# Altium schematic sheet Surface.SchDoc(Surface)
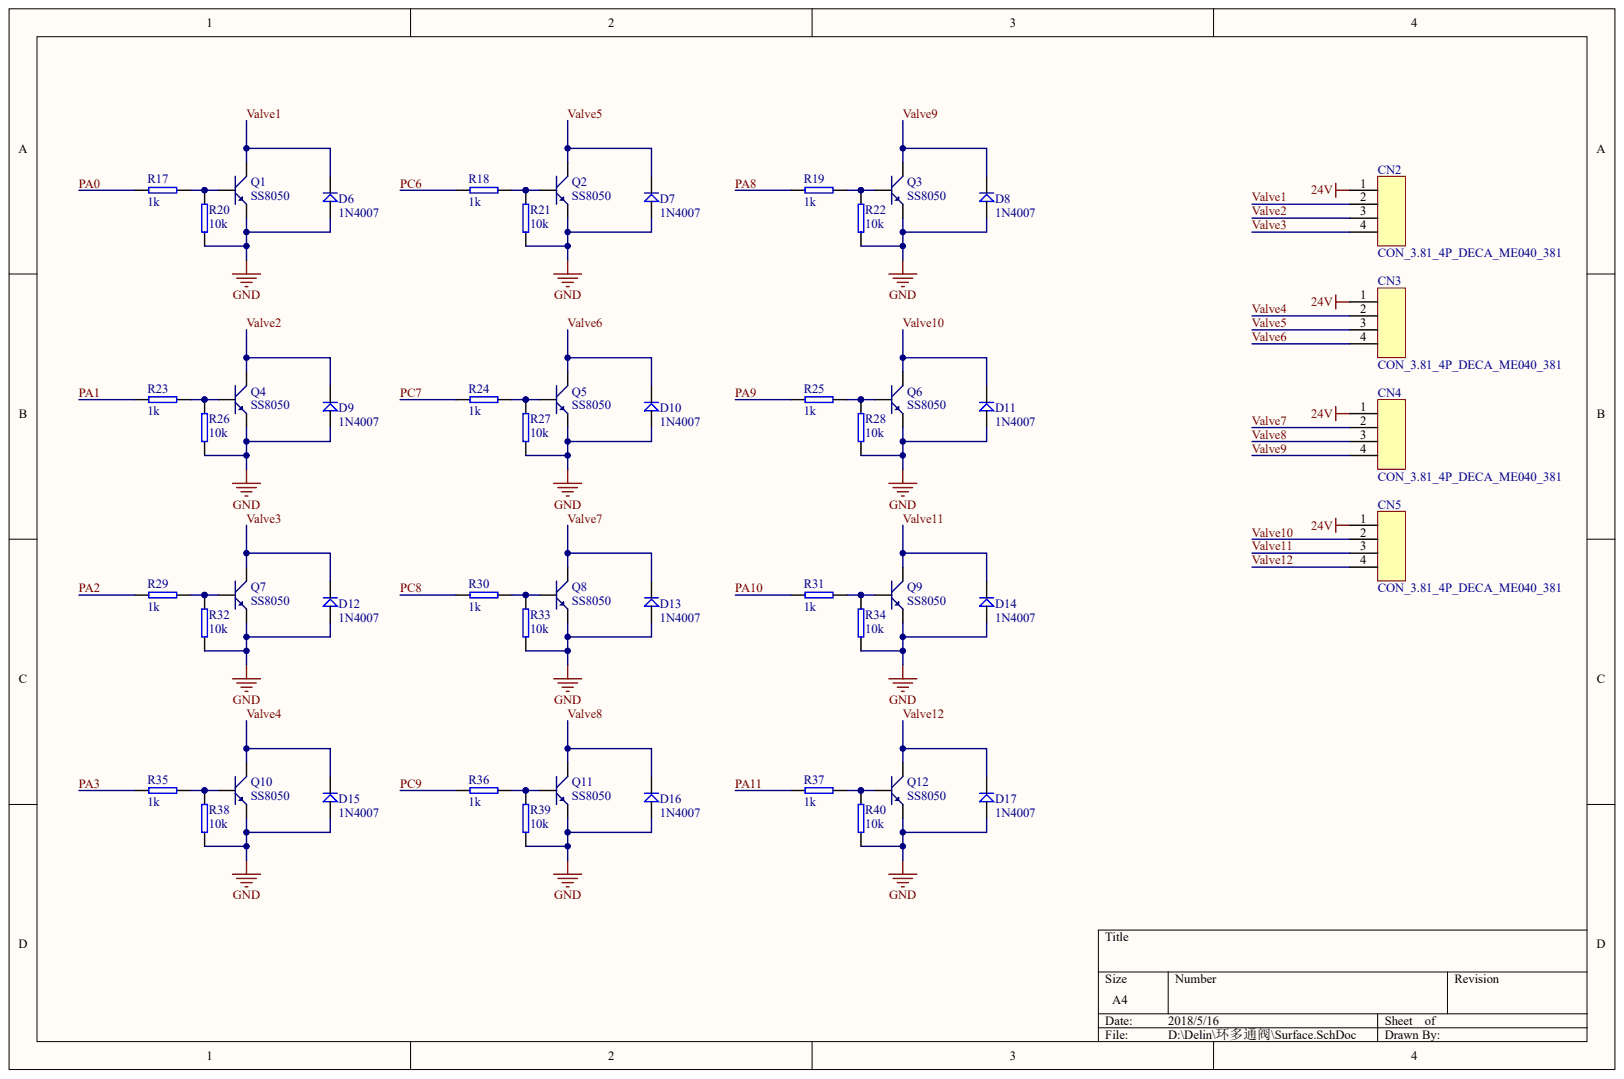
[diagram p0: Surface.SchDoc]

## Parts
CN2  CON_3.81_4P_DECA_ME040_381
CN3  CON_3.81_4P_DECA_ME040_381
CN4  CON_3.81_4P_DECA_ME040_381
CN5  CON_3.81_4P_DECA_ME040_381
D6  1N4007
D7  1N4007
D8  1N4007
D9  1N4007
D10  1N4007
D11  1N4007
D12  1N4007
D13  1N4007
D14  1N4007
D15  1N4007
D16  1N4007
D17  1N4007
Q1  SS8050
Q2  SS8050
Q3  SS8050
Q4  SS8050
Q5  SS8050
Q6  SS8050
Q7  SS8050
Q8  SS8050
Q9  SS8050
Q10  SS8050
Q11  SS8050
Q12  SS8050
R17  1k
R18  1k
R19  1k
R20  10k
R21  10k
R22  10k
R23  1k
R24  1k
R25  1k
R26  10k
R27  10k
R28  10k
R29  1k
R30  1k
R31  1k
R32  10k
R33  10k
R34  10k
R35  1k
R36  1k
R37  1k
R38  10k
R39  10k
R40  10k

## Nets
24V: CN2.1 CN3.1 CN4.1 CN5.1
GND: D6.1 D7.1 D8.1 D9.1 D10.1 D11.1 D12.1 D13.1 D14.1 D15.1 D16.1 D17.1 Q1.1 Q2.1 Q3.1 Q4.1 Q5.1 Q6.1 Q7.1 Q8.1 Q9.1 Q10.1 Q11.1 Q12.1 R20.2 R21.2 R22.2 R26.2 R27.2 R28.2 R32.2 R33.2 R34.2 R38.2 R39.2 R40.2
PA0: R17.2
PA1: R23.2
PA2: R29.2
PA3: R35.2
PA8: R19.2
PA9: R25.2
PA10: R31.2
PA11: R37.2
PC6: R18.2
PC7: R24.2
PC8: R30.2
PC9: R36.2
VALVE1: CN2.2 D6.2 Q1.3
VALVE2: CN2.3 D9.2 Q4.3
VALVE3: CN2.4 D12.2 Q7.3
VALVE4: CN3.2 D15.2 Q10.3
VALVE5: CN3.3 D7.2 Q2.3
VALVE6: CN3.4 D10.2 Q5.3
VALVE7: CN4.2 D13.2 Q8.3
VALVE8: CN4.3 D16.2 Q11.3
VALVE9: CN4.4 D8.2 Q3.3
VALVE10: CN5.2 D11.2 Q6.3
VALVE11: CN5.3 D14.2 Q9.3
VALVE12: CN5.4 D17.2 Q12.3
NetQ1_2: Q1.2 R17.1 R20.1
NetQ2_2: Q2.2 R18.1 R21.1
NetQ3_2: Q3.2 R19.1 R22.1
NetQ4_2: Q4.2 R23.1 R26.1
NetQ5_2: Q5.2 R24.1 R27.1
NetQ6_2: Q6.2 R25.1 R28.1
NetQ7_2: Q7.2 R29.1 R32.1
NetQ8_2: Q8.2 R30.1 R33.1
NetQ9_2: Q9.2 R31.1 R34.1
NetQ10_2: Q10.2 R35.1 R38.1
NetQ11_2: Q11.2 R36.1 R39.1
NetQ12_2: Q12.2 R37.1 R40.1

## Library data of the parts
CN2-CN5 = (no symbol name): Manufacturer: DECA; PartNumber: ME040-381-4P
